# Altium schematic sheet 通讯及预留.SchDoc
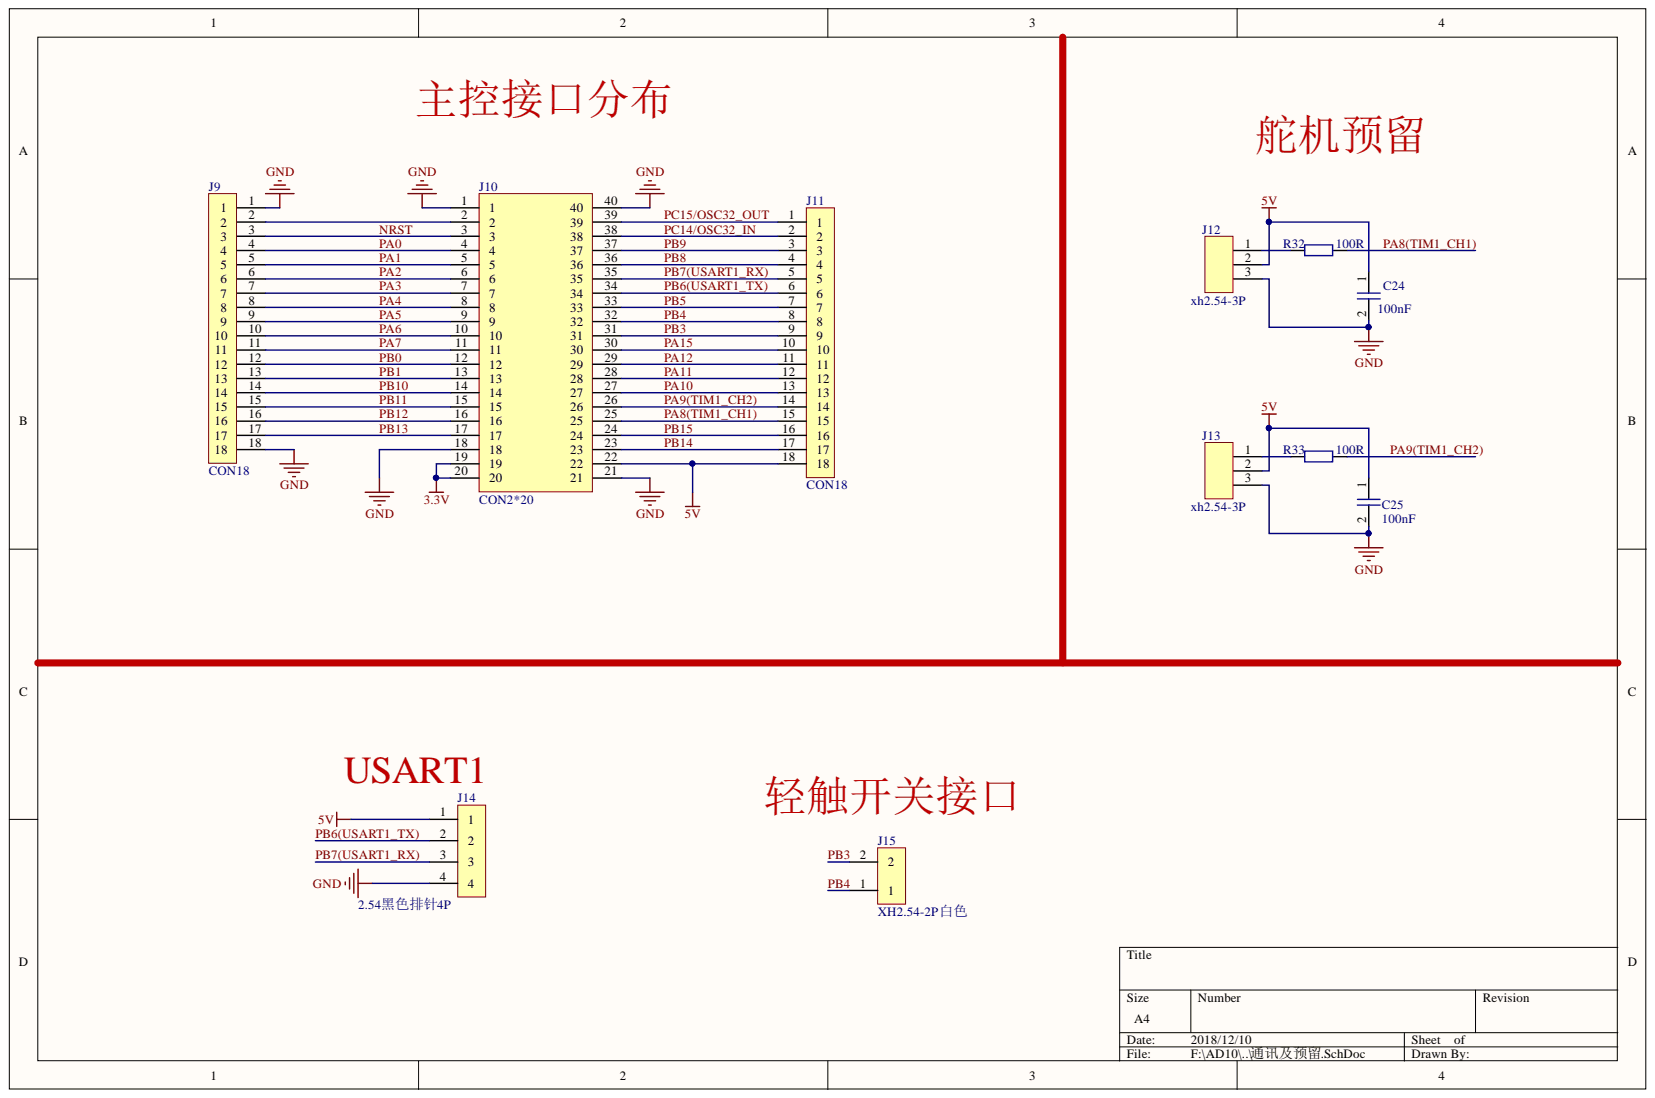
[diagram p0: 通讯及预留.SchDoc]

## Parts
C24  100nF  [LC-0603_C]
C25  100nF  [LC-0603_C]
J9  CON18  [HDR18X1_A]
J10  CON2*20  [CON2*20]
J11  CON18  [HDR18X1_A]
J12  xh2.54-3P  [HDR3X1_A]
J13  xh2.54-3P  [HDR3X1_A]
J14  2.54黑色排针4P  [HDR4_A]
J15  XH2.54-2P 白色  [Header2_white]
R32  100R  [LC-0603_R]
R33  100R  [LC-0603_R]

## Nets
3.3V: J10.19 J10.20
5V: C24.1 C25.1 J10.22 J11.18 J12.2 J13.2 J14.1
GND: C24.2 C25.2 J9.1 J9.18 J10.1 J10.18 J10.21 J10.40 J12.3 J13.3 J14.4
NRST: J9.3 J10.3
PA0: J9.4 J10.4
PA1: J9.5 J10.5
PA2: J9.6 J10.6
PA3: J9.7 J10.7
PA4: J9.8 J10.8
PA5: J9.9 J10.9
PA6: J9.10 J10.10
PA7: J9.11 J10.11
PA8(TIM1_CH1): J10.25 J11.15 R32.2
PA9(TIM1_CH2): J10.26 J11.14 R33.2
PA10: J10.27 J11.13
PA11: J10.28 J11.12
PA12: J10.29 J11.11
PA15: J10.30 J11.10
PB0: J9.12 J10.12
PB1: J9.13 J10.13
PB3: J10.31 J11.9 J15.2
PB4: J10.32 J11.8 J15.1
PB5: J10.33 J11.7
PB6(USART1_TX): J10.34 J11.6 J14.2
PB7(USART1_RX): J10.35 J11.5 J14.3
PB8: J10.36 J11.4
PB9: J10.37 J11.3
PB10: J9.14 J10.14
PB11: J9.15 J10.15
PB12: J9.16 J10.16
PB13: J9.17 J10.17
PB14: J10.23 J11.17
PB15: J10.24 J11.16
PC14/OSC32_IN: J10.38 J11.2
PC15/OSC32_OUT: J10.39 J11.1
NetJ9_2: J9.2 J10.2
NetJ12_1: J12.1 R32.1
NetJ13_1: J13.1 R33.1

## Library data of the parts
C24 C25 = Cap: 无极性电容; Value: 0603; Component Kind: Standard; LatestRevisionDate: 17-Jul-2002; LatestRevisionNote: Re-released for DXP Platform.; PackageReference: RAD-0.3; Published: 8-Jun-2000; Library Name: RM_步兵主控板(梯形板)原理图库.SchLib; Publisher: Altium Limited
J12 J13 = CON3_1: Component Kind: Standard; Library Name: RM_步兵主控板(梯形板)原理图库.SchLib
J14 = CON4: Component Kind: Standard; Library Name: 工作原理库.SchLib
J15 = CON2-(2): Component Kind: Standard; Library Name: RM_步兵主控板(梯形板)原理图库.SchLib
R32 R33 = Res2: Resistor; Value: 0603; Component Kind: Standard; LatestRevisionDate: 17-Jul-2002; LatestRevisionNote: Re-released for DXP Platform.; PackageReference: AXIAL-0.4; Published: 8-Jun-2000; Simulation: RESISTOR; Library Name: RM_步兵主控板(梯形板)原理图库.SchLib; Publisher: Altium Limited
J9 J11 = CON18: Component Kind: Standard; Library Name: 工作原理库.SchLib
J10 = CON2*20: Component Kind: Standard; Library Name: 工作原理库.SchLib
